# Altium schematic sheet POWER_SUPPLY.SchDoc(U_POWER_SUPPLY)
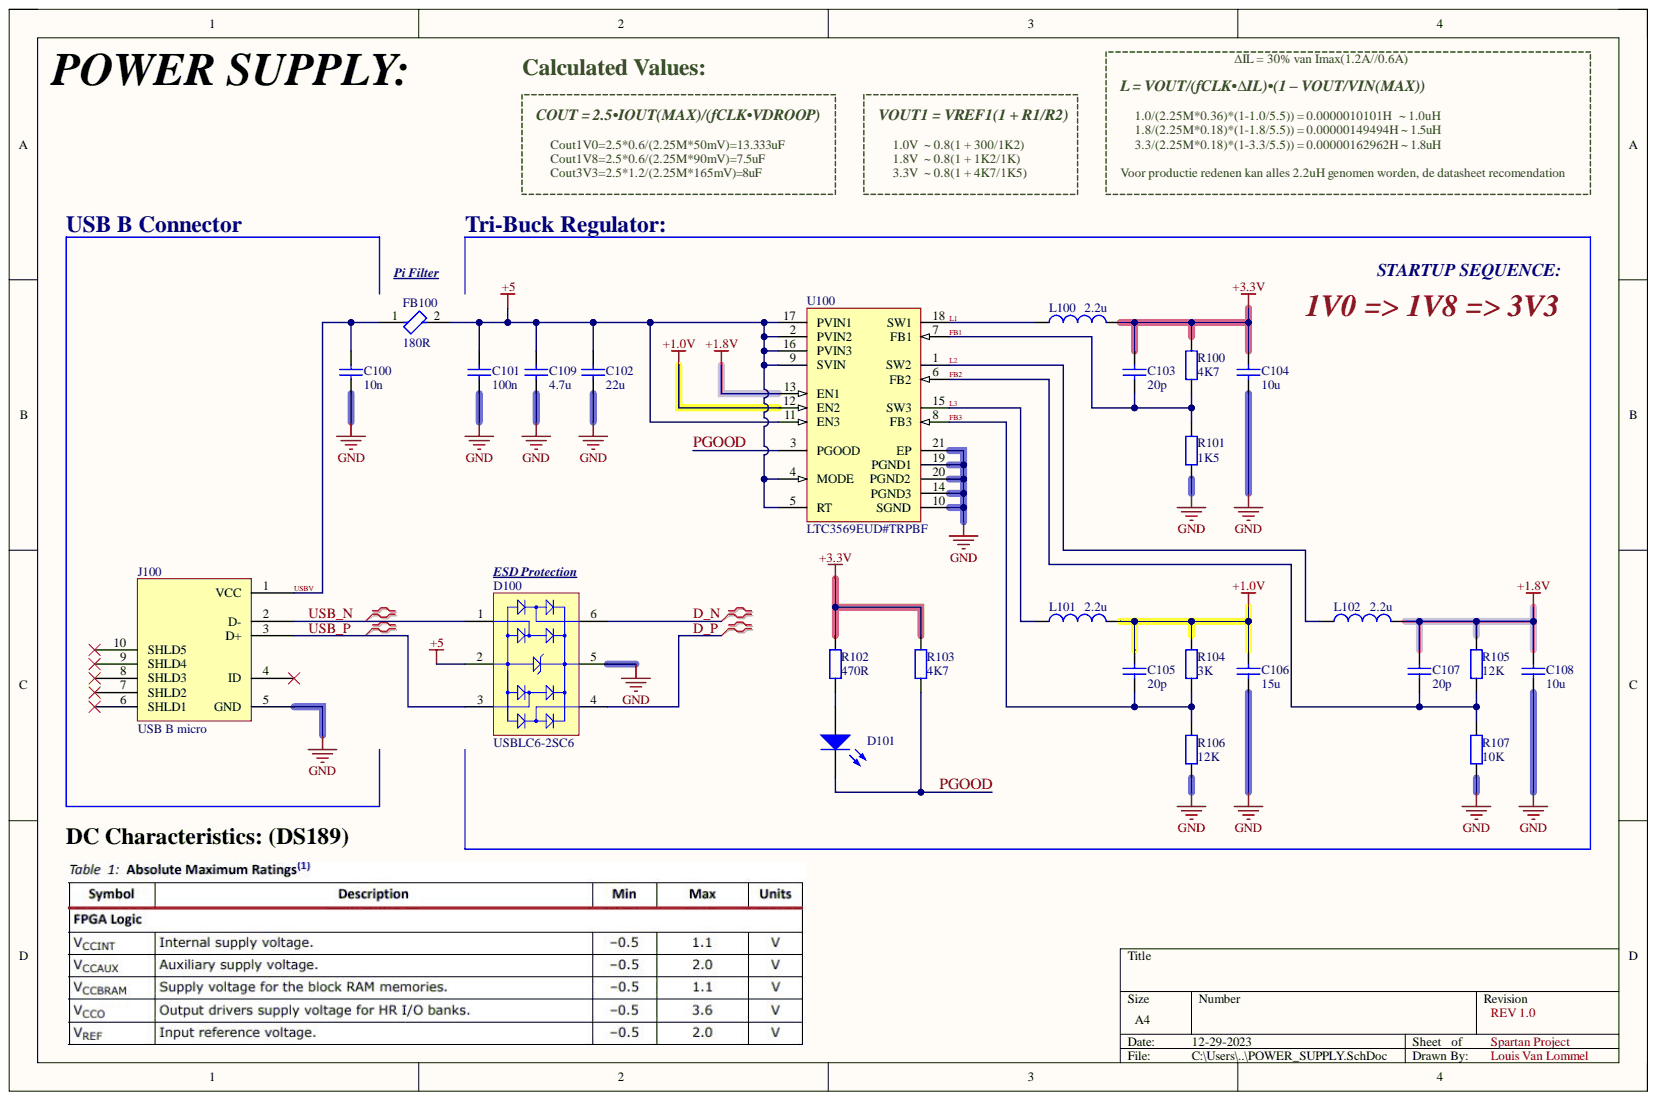
[diagram p0: POWER_SUPPLY.SchDoc]

## Parts
C100  10n  [CAP_0603]
C101  100n  [CAP_0603]
C102  22u  [CAP_1210]
C103  value 20p  [CAP_0603]  (hidden comment: 20pF)
C104  value 10u  [CAP_1210]  (hidden comment: 10uF)
C105  value 20p  [CAP_0603]  (hidden comment: 20pF)
C106  value 15u  [CAP_1210]  (hidden comment: 15uF)
C107  value 20p  [CAP_0603]  (hidden comment: 20pF)
C108  value 10u  [CAP_1210]  (hidden comment: 10uF)
C109  4.7u  [CAP_0603]
D100  USBLC6-2SC6  [STM-SOT23-6L_V]
D101  LED  [LED_0603]  (value not drawn)
FB100  180R  [CAP_0805]
J100  USB B micro  [USB Micro B]
L100  value 2.2u  [IND4x4mm]  (hidden comment: 2.2uH)
L101  value 2.2u  [IND4x4mm]  (hidden comment: 2.2uH)
L102  value 2.2u  [IND4x4mm]  (hidden comment: 2.2uH)
R100  4K7  [RES_0603]
R101  1K5  [RES_0603]
R102  470  value 470R  [RES_0603]
R103  4K7  [RES_0603]
R104  3K  [RES_0603]
R105  12K  [RES_0603]
R106  12K  [RES_0603]
R107  10K  [RES_0603]
U100  LTC3569EUD#TRPBF  [LT-UD-20_N]

## Nets
+1.0V: C105.2 C106.2 L101.2 R104.2 U100.12(EN2)
+1.8V: C107.2 C108.2 L102.2 R105.2 U100.13(EN1)
+3.3V: C103.2 C104.2 L100.2 R100.2 R102.2 R103.2
+5: C101.2 C102.2 C109.2 D100.2 FB100.2 U100.2(PVIN2) U100.4(MODE) U100.5(RT) U100.9(SVIN) U100.11(EN3) U100.16(PVIN3) U100.17(PVIN1)
GND: C100.1 C101.1 C102.1 C104.1 C106.1 C108.1 C109.1 D100.5 J100.5(GND) R101.1 R106.1 R107.1 U100.10(SGND) U100.14(PGND3) U100.19(PGND1) U100.20(PGND2) U100.21(EP)
D_N: D100.6
D_P: D100.4
FB1: C103.1 R100.1 R101.2 U100.7(FB1)
FB2: C107.1 R105.1 R107.2 U100.6(FB2)
FB3: C105.1 R104.1 R106.2 U100.8(FB3)
L1: L100.1 U100.18(SW1)
L2: L102.1 U100.1(SW2)
L3: L101.1 U100.15(SW3)
PGOOD: D101.2 R103.1 U100.3(PGOOD)
USB_N: D100.1 J100.2(D-)
USB_P: D100.3 J100.3(D+)
USBV: C100.2 FB100.1 J100.1(VCC)
NetD101_1: D101.1 R102.1

Not connected: J100 4(ID) 6(SHLD1) 7(SHLD2) 8(SHLD3) 9(SHLD4) 10(SHLD5)

## Library data of the parts
C100-C109 = Cap: Capacitor; Component Kind: Standard; LatestRevisionDate: 17-Jul-2002; LatestRevisionNote: Re-released for DXP Platform.; Library Name: Miscellaneous Devices.IntLib; PackageDescription: Radial Cap, Thru-Hole; 2 Leads; 0.3 in Pin Spacing; PackageReference: RAD-0.3; Published: 8-Jun-2000; Signal Integrity: Cap; Simulation: CAP; Publisher: Altium Limited
  C100: Value: 10n
  C101: Value: 100n
  C102: Value: 22u
  C109: Value: 4.7u
D100 = CMP-2000-05378-1: Very low capacitance ESD protection, 3.5 pF, -40 to 125 degC, 6-Pin SOT23, RoHS, Tape and Reel; Manufacturer: STMicroelectronics; Capacitance Value: 3.5pF; Clamping Voltage: 17V; Configuration: Dual; Direction Type: Uni-Directional; Leakage Current: 0.15uA; Number of Elements: 1; Peak Pulse Current: 5A; Product Depth (mm): 1.75mm; Product Height (mm): 1.3mm; Product Length (mm): 3.05mm; Rad Hardened: No; Reverse Breakdown Voltage: 6V; Reverse Stand-off Voltage: 5V; Suppressor Type: DiodeArrays; Test Current (It): 1mA; Clamping Voltage (Max): 17V; Component Kind: Standard; Datasheet URL: http://www.st.com/st-web-ui/static/active/en/resource/technical/document/datasheet/CD00050750.pdf; Datasheet Version: Rev. 5, 10/2011; Leakage Current (Max): 0.15uA; Library Name: Altium Content Vault; Manufacturer URL: http://www.st.com/; Mounting: SurfaceMount; Mounting Style: SurfaceMount; Operating Temp Range: -40Cto125C; Operating Temperature Classification: Automotive; Operating Temperature Max Deg. C: 125C; Operating Temperature Min Deg. C: -40C; Package / Case: SOT-23; Package Description: 6-Pin Small Outline Transistor, Body 2.925 x 1.625 mm, Pitch 0.95 mm; Package Reference: SOT23-6L; Packaging: TapeandReel; Pin Count: 6; Polarity: Uni-Directional; Working Voltage: 5V; Working Voltage (Max): 5V
D101 = LED0: Typical INFRARED GaAs LED; Component Kind: Standard; LatestRevisionDate: 08-Jul-2005; LatestRevisionNote: Mech Layer 1 removed.; Library Name: Miscellaneous Devices.IntLib; PackageDescription: LED; 2 Leads; PackageReference: LED-0; Published: 8-Jun-2000; Simulation: LED0; Publisher: Altium Limited
FB100 = Ferrite Bead: Chip Ferrite Bead, 0402, 1000Ω @ 100MHz, 0.65Ω, 25%, 300mA; DC Current: 200mA; DC Resistance (DCR): 650mR; DC Resistance-Max: 1R; Impedance: 1kR; Number of Circuits: 1; Shielding: Unshielded; Case Code (Imperial): 0402; Case Code (Metric): 1005; Case/Package: 0402; Component Kind: Standard; Core Material: Ferrite; Current Rating: 300mA; Depth: 0.55mm; Frequency: 100MHz; Height: 0.5mm; Lead Free: Lead Free; Length: 1mm; Library Name: Schematic_Symbols.SchLib; Material: Ferrite; Max Current Rating: 300mA; Max DC Current: 200mA; Max Operating Temperature: 125°C; Military Standard: Not; Min Operating Temperature: -55°C; Number of Lines: 1; Number of Pins: 2; Package Quantity: 1; Packaging: Tape and Reel; Radiation Hardening: No; REACH SVHC: No SVHC; RoHS Compliant: Yes; Series: BLM15AG_SN; Termination: SMD/SMT; Test Frequency: 100MHz; Tolerance: 25%; Width: 0.5mm
J100 = USB micro B: Component Kind: Standard; Library Name: Schematic_Symbols.SchLib
L100-L102 = Inductor: Code_IEC: 1005; Code_JEITA: 0402; Component Kind: Standard; Description: Inductor; LatestRevisionDate: 29-May-2009; LatestRevisionNote: IPC-7351 Footprint Added.; Library Name: Miscellaneous Devices.IntLib; PackageDescription: Chip Inductor; PackageReference: 0402-A; Published: 8-Jun-2000; Signal Integrity: Inductor; Simulation: INDUCTOR; Publisher: Altium Limited
R100-R102 R104-R107 = Res2: Resistor; Component Kind: Standard; LatestRevisionDate: 17-Jul-2002; LatestRevisionNote: Re-released for DXP Platform.; Library Name: Miscellaneous Devices.IntLib; PackageDescription: Axial Device, Thru-Hole; 2 Leads; 0.4 in Pin Spacing; PackageReference: AXIAL-0.4; Published: 8-Jun-2000; Signal Integrity: Res; Simulation: RESISTOR; Publisher: Altium Limited
  R100: Value: 4K7
  R101: Value: 1K5
  R104: Value: 3K
  R105 R106: Value: 12K
  R107: Value: 10K
R103 = Res2: DNP R103; Component Kind: Standard; LatestRevisionDate: 17-Jul-2002; LatestRevisionNote: Re-released for DXP Platform.; Library Name: Miscellaneous Devices.IntLib; PackageDescription: Axial Device, Thru-Hole; 2 Leads; 0.4 in Pin Spacing; PackageReference: AXIAL-0.4; Published: 8-Jun-2000; Signal Integrity: Res; Simulation: RESISTOR; Value: 4K7; Publisher: Altium Limited
U100 = CMP-0492-02156-1: Triple Buck Regulator with 1.2 A and Two 600 mA Outputs and Individual Programmable References, 20-pin QFN (UD-20), -40 to 125 degC, Pb-Free, Tape and Reel; Manufacturer: Linear Technology; Frequency Adjust Range: 1MHz - 3MHz; Number of Outputs: 3; Component Kind: Standard; ComponentLink1Description: Manufacturer URL; ComponentLink1URL: http://www.linear.com/; ComponentLink2Description: Datasheet; ComponentLink2URL: http://cds.linear.com/docs/en/datasheet/3569fd.pdf; ComponentLink3Description: Package Specification; ComponentLink3URL: http://cds.linear.com/docs/en/packaging/QFN_20_05-08-1720.pdf; DatasheetVersion: Rev. D; Library Name: Altium Content Vault; Mounting Technology: Surface Mount; PackageDescription: 20-Pin Plastic QFN, Body 3 x 3 mm, Pitch 0.4 mm; PackageReference: UD-20; PackageVersion: Rev. A, 06/2010; Packing: Tape and Reel; PartNumber: LTC3569EUD#TRPBF; RoHS: TRUE
